# Altium schematic sheet Memory.SchDoc(Memory)
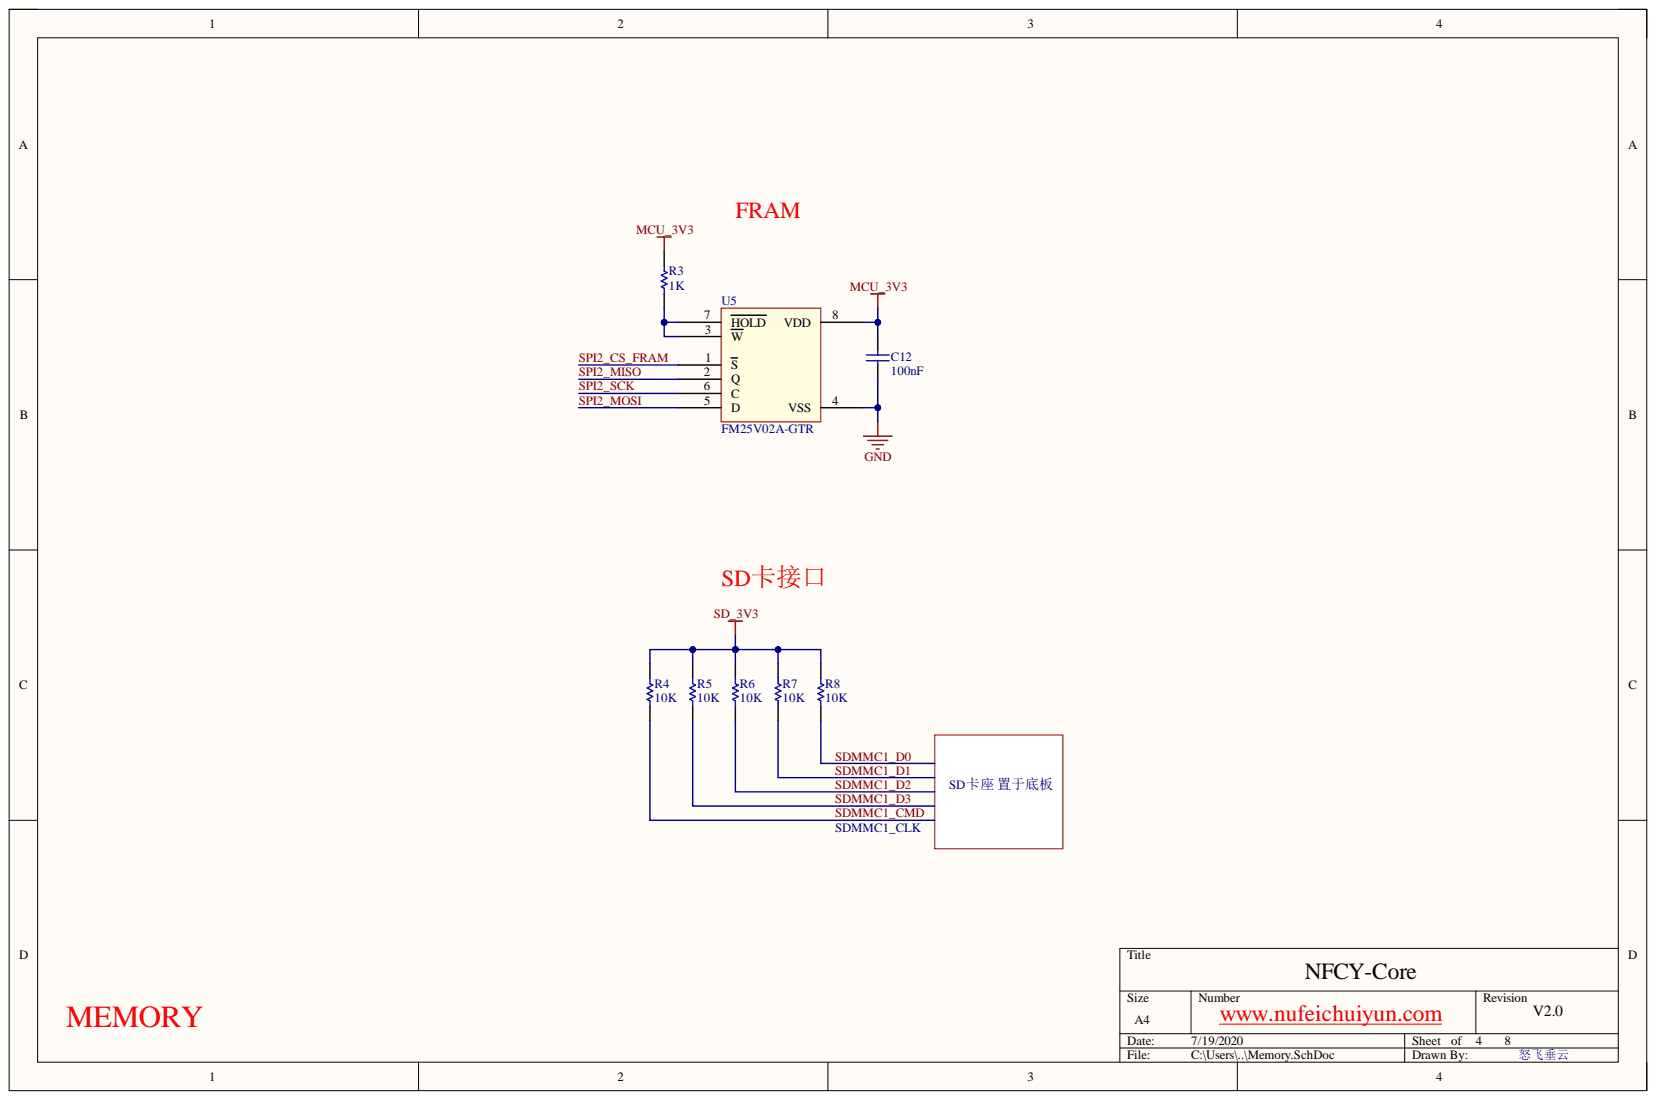
[diagram p0: Memory.SchDoc]

## Parts
C12  value 100nF  [CAP0402]  (hidden comment: CL05B104KO5NNNC)
R3  value 1K  [RES0402]  (hidden comment: RC0402FR-071KL)
R4  value 10K  [RES0402]  (hidden comment: RC0402FR-0710KL)
R5  value 10K  [RES0402]  (hidden comment: RC0402FR-0710KL)
R6  value 10K  [RES0402]  (hidden comment: RC0402FR-0710KL)
R7  value 10K  [RES0402]  (hidden comment: RC0402FR-0710KL)
R8  value 10K  [RES0402]  (hidden comment: RC0402FR-0710KL)
U5  FM25V02A-GTR  [SOIC-8]

## Nets
GND: C12.1 U5.4(VSS)
MCU_3V3: C12.2 R3.1 U5.8(VDD)
SD_3V3: R4.2 R5.2 R6.2 R7.2 R8.2
SDMMC1_CMD: R4.1
SDMMC1_D0: R8.1
SDMMC1_D1: R7.1
SDMMC1_D2: R6.1
SDMMC1_D3: R5.1
SPI2_CS_FRAM: U5.1(S)
SPI2_MISO: U5.2(Q)
SPI2_MOSI: U5.5(D)
SPI2_SCK: U5.6(C)
NetR3_2: R3.2 U5.3(W) U5.7(HOLD)

## Library data of the parts
C12 = Cap: 贴片电容; manufacturer: SAMSUNG(三星); Component Kind: Standard; LatestRevisionDate: 17-Jul-2002; LatestRevisionNote: Re-released for DXP Platform.; Library Name: Miscellaneous Devices.IntLib; PackageDescription: Radial Cap, Thru-Hole; 2 Leads; 0.3 in Pin Spacing; PackageReference: RAD-0.3; Published: 8-Jun-2000; Signal Integrity: Cap; Simulation: CAP; Publisher: Altium Limited
R3-R8 = Res1: 贴片电阻; manufacturer: YAGEO(国巨); Component Kind: Standard; LatestRevisionDate: 17-Jul-2002; LatestRevisionNote: Re-released for DXP Platform.; Library Name: Miscellaneous Devices.IntLib; PackageDescription: Axial Device, Thru-Hole; 2 Leads; 0.3 in Pin Spacing; PackageReference: AXIAL-0.3; Published: 8-Jun-2000; Signal Integrity: Res; Simulation: RESISTOR; Publisher: Altium Limited
U5 = FM25V02A-GTR: 铁电存储器; manufacturer: CYPRESS(赛普拉斯); Component Kind: Standard; Library Name: Chip.SchLib
